# Altium schematic sheet SH6 - BRUSHED MOTOR CONTROLLER.SchDoc
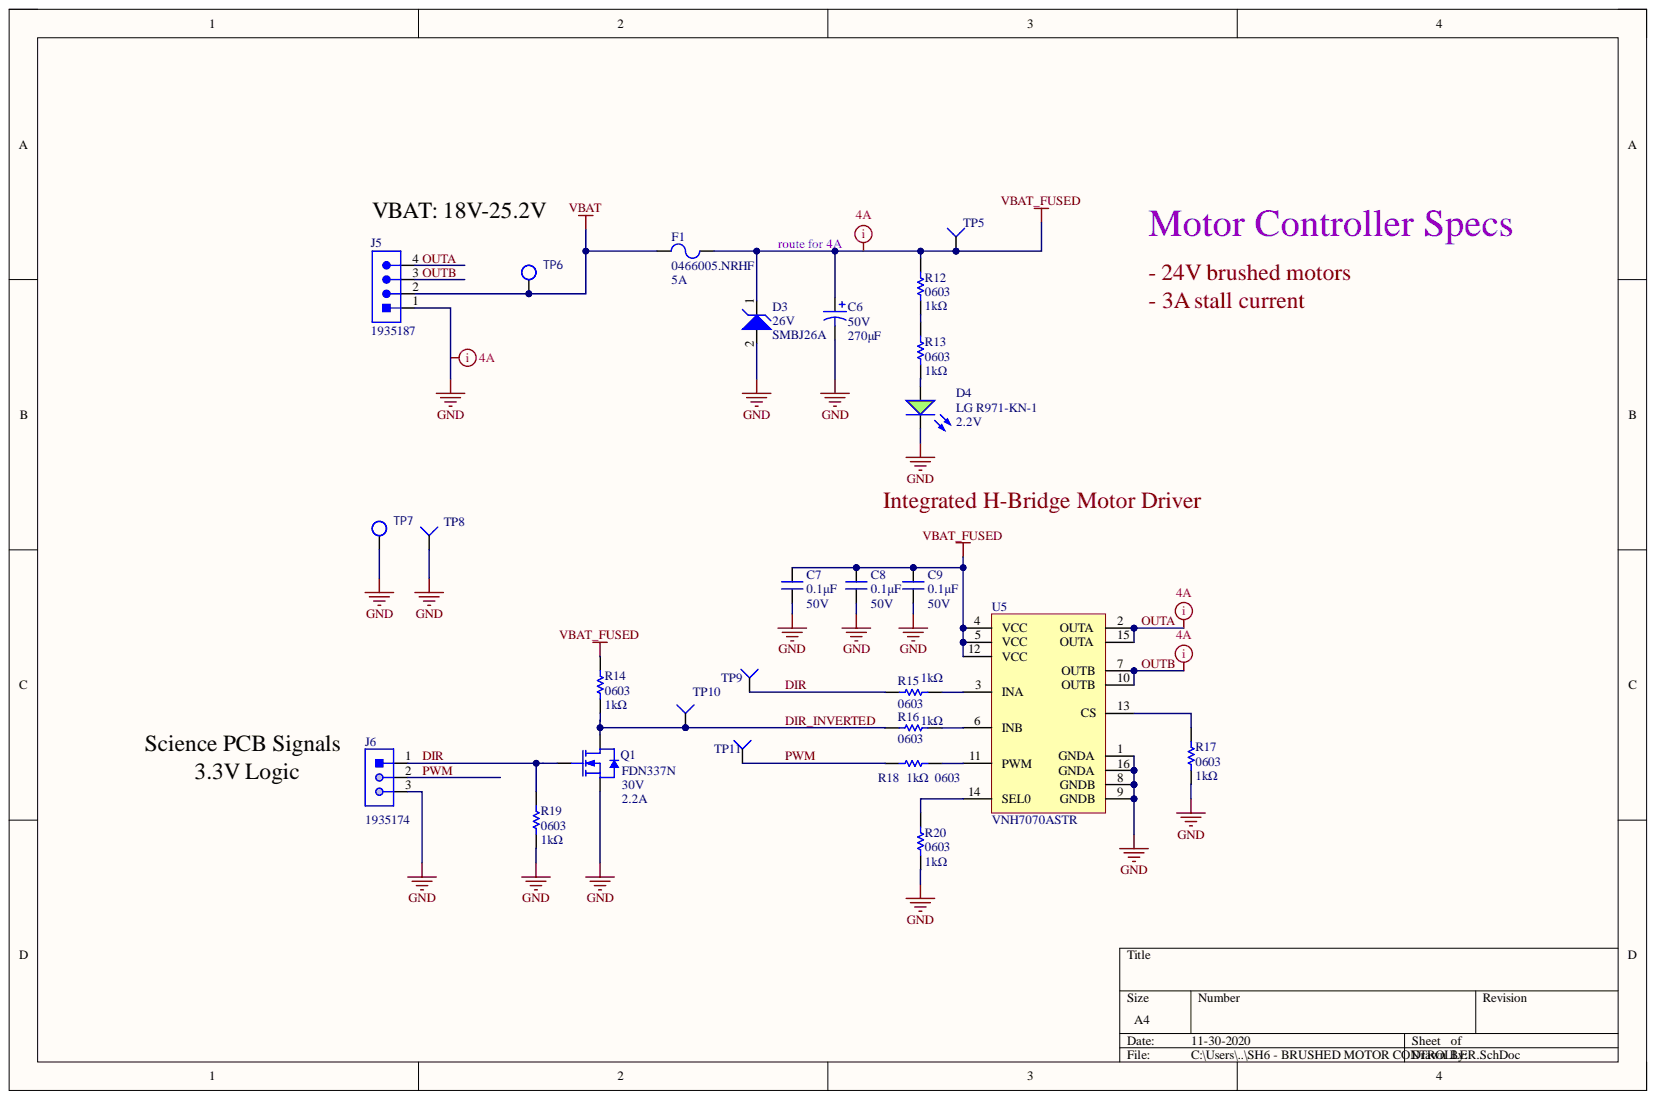
[diagram p0: SH6 - BRUSHED MOTOR CONTROLLER.SchDoc]

## Parts
C6  value 270μF  Voltage Rating: 50V  [RADIAL_PANASONIC_G]  (hidden comment: CAP_270uF_50V_ALUM)
C7  value 0.1μF  Voltage Rating: 50V  [CAP0603]  (hidden comment: CAP_0.1uF_50V_0603)
C8  value 0.1μF  Voltage Rating: 50V  [CAP0603]  (hidden comment: CAP_0.1uF_50V_0603)
C9  value 0.1μF  Voltage Rating: 50V  [CAP0603]  (hidden comment: CAP_0.1uF_50V_0603)
D3  Manufacturer Part Number 1: SMBJ26A  Reverse Standoff Voltage: 26V  [TVS_DIODE_UNIDIRECTIONAL_SMB]  (hidden comment: TVS_DIODE_UNIDIR_26V)
D4  LG R971-KN-1  Forward Voltage Drop: 2.2V  [LED_0805_GREEN]
F1  Current: 5A  Manufacturer Part Number 1: 0466005.NRHF  [FUSE_1206]  (hidden comment: FUSE_5A_1206)
J5  CON_4M_TERM  [1935187]  (value not drawn)
J6  CON_3M_TERM  [1935174]  (value not drawn)
Q1  Continuous Drain Current (ID): 2.2A  Drain to Source Breakdown Voltage: 30V  Manufacturer Part Number: FDN337N  [NMOS_SSOT-3]  (hidden comment: NMOS_30V_2.2A)
R12  value 1kΩ  Case Code (Imperial): 0603  [RESC0603]  (hidden comment: RES_1kΩ_0603)
R13  value 1kΩ  Case Code (Imperial): 0603  [RESC0603]  (hidden comment: RES_1kΩ_0603)
R14  value 1kΩ  Case Code (Imperial): 0603  [RESC0603]  (hidden comment: RES_1kΩ_0603)
R15  value 1kΩ  Case Code (Imperial): 0603  [RESC0603]  (hidden comment: RES_1kΩ_0603)
R16  value 1kΩ  Case Code (Imperial): 0603  [RESC0603]  (hidden comment: RES_1kΩ_0603)
R17  value 1kΩ  Case Code (Imperial): 0603  [RESC0603]  (hidden comment: RES_1kΩ_0603)
R18  value 1kΩ  [RESC0603]  (hidden comment: RES_1kΩ_0603)
R19  value 1kΩ  Case Code (Imperial): 0603  [RESC0603]  (hidden comment: RES_1kΩ_0603)
R20  value 1kΩ  Case Code (Imperial): 0603  [RESC0603]  (hidden comment: RES_1kΩ_0603)
TP5  TESTPOINT_1MM_CIRCLE  [TESTPOINT_CIRCLE_1MM]  (value not drawn)  (no BOM)
TP6  TESTPOINT_LOOP  [TESTPOINT_LOOP]  (value not drawn)
TP7  TESTPOINT_LOOP  [TESTPOINT_LOOP]  (value not drawn)
TP8  TESTPOINT_1MM_SQUARE  [TESTPOINT_SQUARE_1MM]  (value not drawn)  (no BOM)
TP9  TESTPOINT_1MM_CIRCLE  [TESTPOINT_CIRCLE_1MM]  (value not drawn)  (no BOM)
TP10  TESTPOINT_1MM_CIRCLE  [TESTPOINT_CIRCLE_1MM]  (value not drawn)  (no BOM)
TP11  TESTPOINT_1MM_CIRCLE  [TESTPOINT_CIRCLE_1MM]  (value not drawn)  (no BOM)
U5  Interface: PWM  Manufacturer Part Number 1: VNH7070ASTR  [SO-16N]  (hidden comment: IC_MOTOR_DRIVER_ST)

## Nets
GND: C6.2 C7.1 C8.1 C9.2 D3.2 D4.2 J5.1 J6.3 Q1.2 R17.1 R19.1 R20.1 TP7.1 TP8.1 U5.1(GNDA) U5.8(GNDB) U5.9(GNDB) U5.16(GNDA)
VBAT: F1.1 J5.2 TP6.1
VBAT_FUSED: C6.1 C7.2 C8.2 C9.1 D3.1 F1.2 R12.2 R14.2 TP5.1 U5.4(VCC) U5.5(VCC) U5.12(VCC)
DIR: J6.1 Q1.1 R15.1 R19.2 TP9.1
DIR_INVERTED: Q1.3 R14.1 R16.1 TP10.1
OUTA: J5.4 U5.2(OUTA) U5.15(OUTA)
OUTB: J5.3 U5.7(OUTB) U5.10(OUTB)
PWM: J6.2 R18.1 TP11.1
NetD4_1: D4.1 R13.1
NetR12_1: R12.1 R13.2
NetR15_2: R15.2 U5.3(INA)
NetR16_2: R16.2 U5.6(INB)
NetR17_2: R17.2 U5.13(CS)
NetR18_2: R18.2 U5.11(PWM)
NetR20_2: R20.2 U5.14(SEL0)

## Library data of the parts
C6 = CAP_270uF_50V_ALUM: CAP ALUM 270uF 50VDC 20% SMD; Manufacturer 1: Panasonic Electronic Components; Manufacturer Part Number 1: EEE-FN1H271UP; Component Kind: Standard; Mount: Surface Mount; Supplier 1: Digi-Key; Supplier Part Number 1: 10-EEE-FN1H271UPCT-ND; Library Name: UWRT_Schematic_Library_2020.SchLib
C7-C9 = CAP_0.1uF_50V_0603: CAP CER 0.1UF 50V X7R 0603; Manufacturer 1: Yageo; Manufacturer Part Number 1: CC0603KRX7R9BB104; Case Code (Imperial): 0603; Case Code (Metric): 1608; Component Kind: Standard; Dielectric: X7R; Dimensions: 0.063" L x 0.031" W (1.60mm x 0.80mm); Mount: Surface Mount; Package: 0603 (1608 Metric); Signal Integrity: Cap; Simulation: CAP; Supplier 1: Digi-Key; Supplier Part Number 1: 311-1344-1-ND; Temperature Coefficient: X7R; Library Name: UWRT_Schematic_Library_2020.SchLib
D3 = TVS_DIODE_UNIDIR_26V: TVS DIODE 26V 42.1V DO214AA; Manufacturer 1: Littelfuse; Min Breakdown Voltage: 28.9V; Clamping Voltage: 42.1V; Component Kind: Standard; Mount: Surface Mount; Package: DO-214AA, SMB; Supplier 1: Digi-Key; Supplier Part Number 1: SMBJ26ALFCT-ND; Library Name: UWRT_Schematic_Library_2020.SchLib
D4 = DIO_LED_G: LED GREEN DIFFUSED 0805 SMD; Manufacturer 1: OSRAM; Component Kind: Standard; Manufacturer Part Number 1: LG R971-KN-1; Mount: Surface Mount; Package: 0805 (2012 Metric); Supplier 1: Digi-Key; Supplier Part Number 1: 475-1410-1-ND; Library Name: UWRT_Schematic_Library_2020.SchLib
F1 = FUSE_5A_1206: FUSE SMD 5A 63V; Manufacturer 1: Littelfuse; Approvals: UL,CSA; DC Cold Resistance: 31mR; Fuse Type: Board Mount (Cartridge Style Excluded); Power Dissipation: 157.5mW; Voltage Rating (AC): 32V; Case Code (Imperial): 1206; Case Code (Metric): 3216; Case/Package: 1206; Component Kind: Standard; Current Rating: 5A; Depth: 1.52mm; Height: 0.023inch; Housing Material: Ceramic; Length: 3.18mm; Max Operating Temperature: 90°C; Min Operating Temperature: -55°C; Mount: Surface Mount; Supplier 1: Digi-Key; Supplier Part Number 1: F6019CT-ND; Voltage Rating (DC): 32V; Width: 0.06inch; Library Name: UWRT_Schematic_Library_2020.SchLib
J5 = CON_4M_TERM: TERM BLK 4POS SIDE ENTRY 5MM PCB; Manufacturer 1: Phoenix Contact; Current: 18A; Screw Thread: M2.6; Voltage: 300V; Wire Gauge: 12-26AWG; Wire Termination: Screw; Component Kind: Standard; Manufacturer Part Number 1: 1935187; Mounting Type: Through Hole; Pitch: 5mm; Supplier 1: Digi-Key; Supplier Part Number 1: 277-1579-ND; Library Name: UWRT_Schematic_Library_2020.SchLib
J6 = CON_3M_TERM: TERM BLK 3POS SIDE ENTRY 5MM; Manufacturer 1: Phoenix Contact; Approvals: UL,VDE,CB; Current: 18A; Current Rating: 17.5A; Max Current Rating: 16A; Max Supply Voltage: 250V; Number of Circuits: 3; Number of Levels: 1; Voltage: 300V; Voltage Rating (DC): 320V; Wire Gauge (Max): 26AWG; Wire Gauge (Min): 12AWG; Category: Terminal Blocks; Color: Green; Component Kind: Standard; Contact Material: Copper,Tin; Depth: 9mm; Flammability Rating: UL94 V-0; Height: 11.3mm; Housing Color: Green; Housing Material: Polyamide; Insulation Material: Nylon; Length: 9mm; Manufacturer Part Number 1: 1935174; Material: Nylon; Mount: Through Hole; Number of Contacts: 3; Number of Pins: 3; Number of Poles: 3; Number of Positions: 3; Number of Positions Per Level: 3; Number of Rows: 1; Orientation: Straight; Pitch: 5mm; REACH SVHC: Unknown; Stripping Length: 5mm; Supplier 1: Digi-Key; Supplier Part Number 1: 277-1578-ND; Termination: Solder; Voltage Rating: 250V; Weight: 0.100531oz; Width: 15mm; Wire/Cable Gauge: 26AWG; Library Name: UWRT_Schematic_Library_2020.SchLib
Q1 = NMOS_30V_2.2A: MOSFET N-CH 30V 2.2A SSOT3; Manufacturer: ON Semiconductor / Fairchild; Drain to Source Resistance: 65mR; Element Configuration: Single; Fall Time: 4ns; Gate to Source Voltage (Vgs): 8V; Input Capacitance: 300pF; Max Power Dissipation: 500mW; Nominal Vgs: 700mV; Number of Elements: 1; Rise Time: 10ns; Turn-Off Delay Time: 17ns; Case/Package: TO-236-3; Category: MOSFETs; Component Kind: Standard; Current Rating: 2.2A; Drain to Source Voltage (Vdss): 30V; Dual Supply Voltage: 30V; Max Operating Temperature: 150°C; Mount: Surface Mount; On-State Resistance: 65mR; Power Dissipation: 500mW; Rds On Max: 65mR; Supplier 1: Digi-Key; Supplier Part Number 1: FDN337NCT-ND; Threshold Voltage: 700mV; Turn-On Delay Time: 4ns; Voltage Rating (DC): 30V; Library Name: UWRT_Schematic_Library_2020.SchLib
R12-R20 = RES_1kΩ_0603_5%: RES 1K OHM 5% 1/10W 0603; Manufacturer 1: Yageo; Manufacturer Part Number 1: RC0603JR-071KL; Case Code (Metric): 1608; Component Kind: Standard; Dimensions: 0.063" L x 0.031" W (1.60mm x 0.80mm); Mount: Surface Mount; Package: 0603 (1608 Metric); Supplier 1: Digi-Key; Supplier Part Number 1: 311-1.0KGRCT-ND; Tolerance: 5%; Library Name: UWRT_Schematic_Library_2020.SchLib
  R18: Case Code (Imperial): 0603
TP5 TP9-TP11 = TESTPOINT_1MM_CIRCLE: TESTPOINT 1MM CIRCLE; Library Name: UWRT_Schematic_Library_2020.SchLib
TP6 TP7 = TESTPOINT_LOOP: PC TEST POINT MINIATURE WHITE; Manufacturer 1: Keystone Electronics; Manufacturer Part Number 1: 5002; Component Kind: Standard; Mount: Through Hole; Supplier 1: Digi-Key; Supplier Part Number 1: 36-5002-ND; Library Name: UWRT_Schematic_Library_2020.SchLib
TP8 = TESTPOINT_1MM_SQUARE: TESTPOINT 1MM SQUARE; Library Name: UWRT_Schematic_Library_2020.SchLib
U5 = IC_MOTOR_DRIVER_ST: IC H-BRIDGE MOTOR DRIVER 16-PINS STMicroelectronics VNH7070ASTR, SOIC; Logic Function: AND; Output Current: 15A; Case/Package: SOIC; Component Kind: Standard; Manufacturer 1: STMicroelectronics; Max Operating Temperature: 150°C; Min Operating Temperature: -40°C; Mount: Surface Mount; Number of Pins: 16; Supplier 1: Digi-Key; Supplier Part Number 1: 497-17630-1-ND; Library Name: UWRT_Schematic_Library_2020.SchLib
